# Altium schematic sheet Debug.SchDoc(Debug)
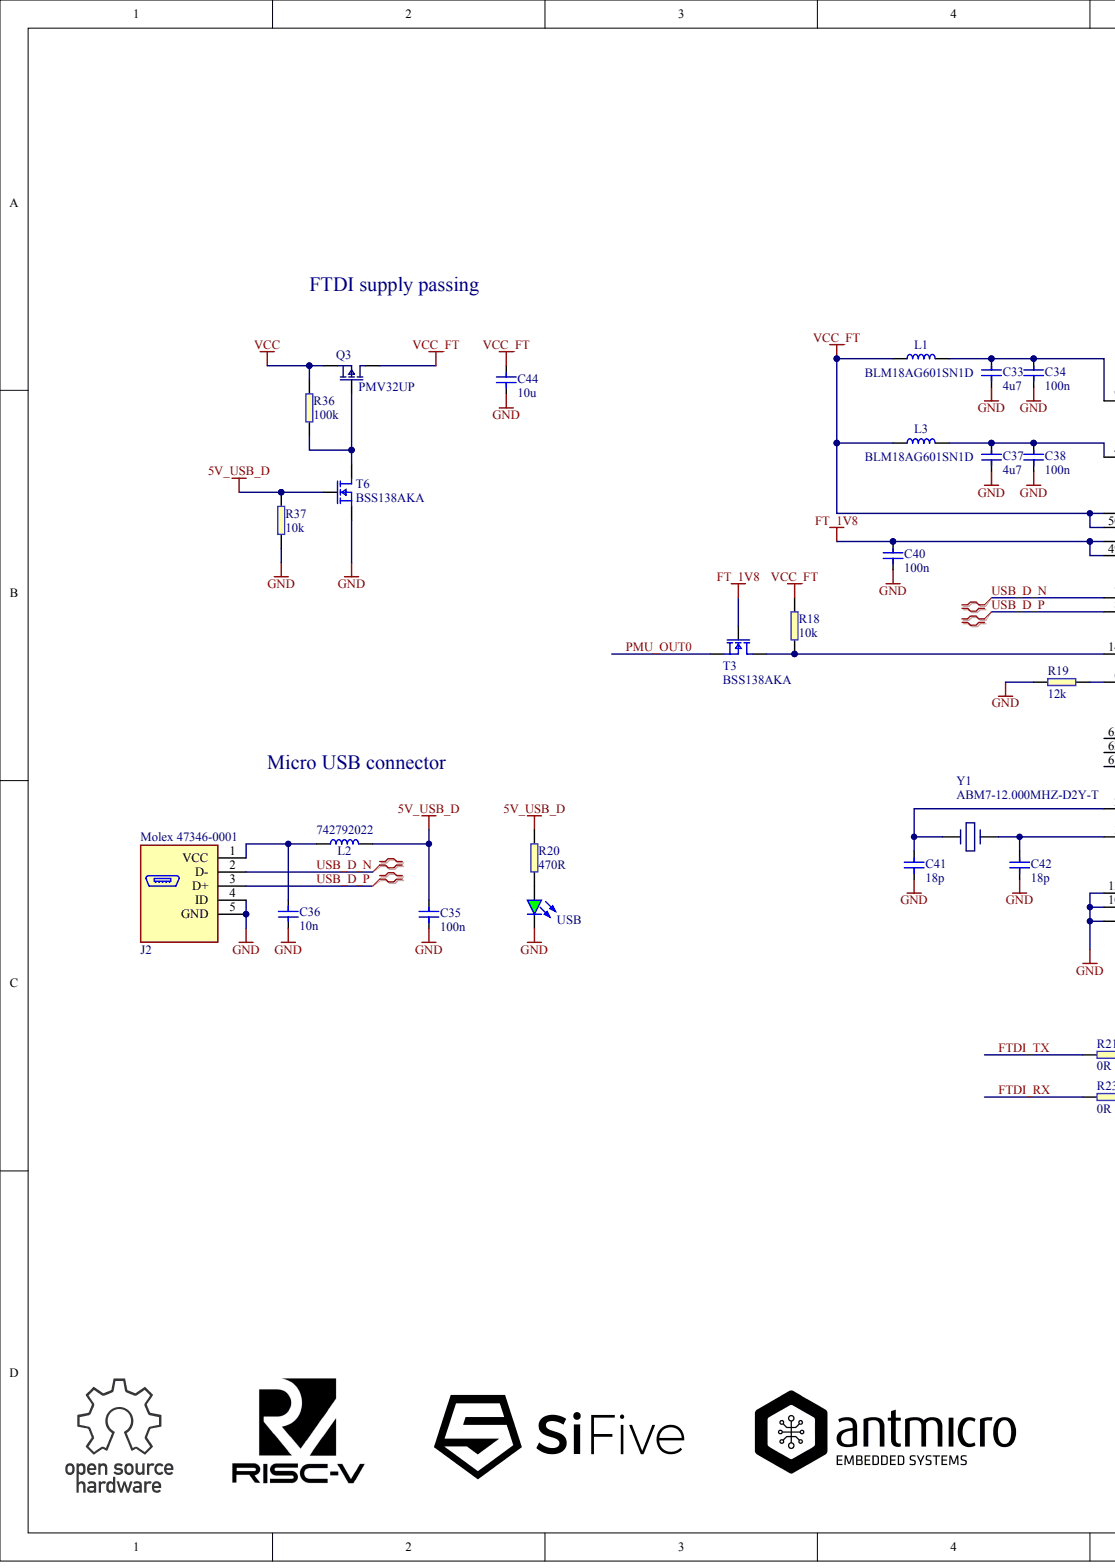
[diagram p0: Debug.SchDoc, left]
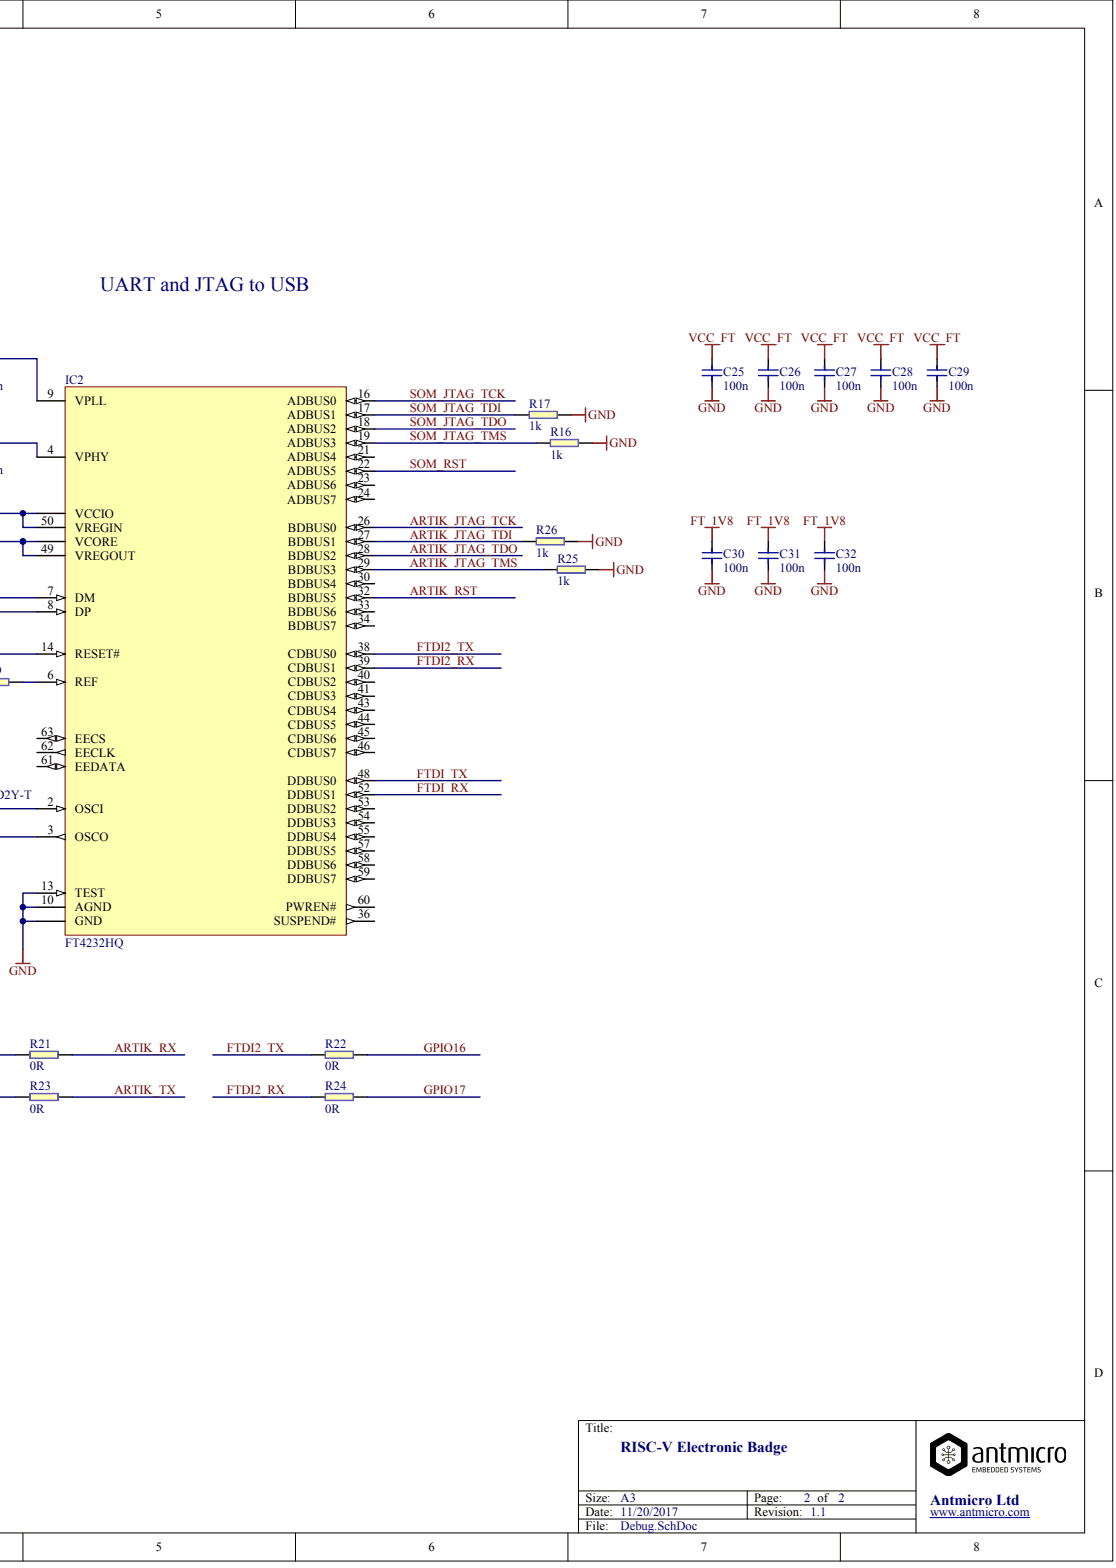
[diagram p1: Debug.SchDoc, right]

## Parts
C25  100n
C26  100n
C27  100n
C28  100n
C29  100n
C30  100n
C31  100n
C32  100n
C33  4u7
C34  100n
C35  100n
C36  10n
C37  4u7
C38  100n
C40  100n
C41  18p
C42  18p
C44  10u
IC2  FT4232HQ
J2  Manufacturer: Molex  (hidden comment: Molex 47346-0001)
L1  BLM18AG601SN1D
L2  742792022
L3  BLM18AG601SN1D
Q3  PMV32UP
R16  1k
R17  1k
R18  10k
R19  12k
R20  470R
R21  0R
R22  0R
R23  0R
R24  0R
R25  1k
R26  1k
R36  100k
R37  10k
T3  BSS138AKA
T6  BSS138AKA
USB  KPT-1608SGC  (value not drawn)
Y1  value ABM7-12.000MHZ-D2Y-T  (hidden comment: ABRACON - ABM7-12.000MHZ-D2Y-T - CRYSTAL, 12MHZ, 18PF, SMD)

## Nets
5V_USB_D: C35.2 L2.1 R20.2 R37.1 T6.1
FT_1V8: C30.2 C31.2 C32.2 C40.2 IC2.49(VREGOUT) IC2.VCORE T3.1
GND: C25.1 C26.1 C27.1 C28.1 C29.1 C30.1 C31.1 C32.1 C33.1 C34.1 C35.1 C36.2 C37.1 C38.1 C40.1 C41.1 C42.1 C44.1 IC2.10(AGND) IC2.13(TEST) IC2.GND J2.4(ID) J2.5(GND) R16.1 R17.1 R19.1 R25.1 R26.1 R37.2 T6.2 USB.K
VCC: Q3.2 R36.2
VCC_FT: C25.2 C26.2 C27.2 C28.2 C29.2 C44.2 IC2.50(VREGIN) IC2.VCCIO L1.1 L3.1 Q3.3 R18.1
ARTIK_JTAG_TCK: IC2.26(BDBUS0)
ARTIK_JTAG_TDI: IC2.27(BDBUS1) R26.2
ARTIK_JTAG_TDO: IC2.28(BDBUS2)
ARTIK_JTAG_TMS: IC2.29(BDBUS3) R25.2
ARTIK_RST: IC2.32(BDBUS5)
ARTIK_RX: R21.2
ARTIK_TX: R23.2
FTDI2_RX: IC2.39(CDBUS1) R24.1
FTDI2_TX: IC2.38(CDBUS0) R22.1
FTDI_RX: IC2.52(DDBUS1) R23.1
FTDI_TX: IC2.48(DDBUS0) R21.1
GPIO16: R22.2
GPIO17: R24.2
PMU_OUT0: T3.2
SOM_JTAG_TCK: IC2.16(ADBUS0)
SOM_JTAG_TDI: IC2.17(ADBUS1) R17.2
SOM_JTAG_TDO: IC2.18(ADBUS2)
SOM_JTAG_TMS: IC2.19(ADBUS3) R16.2
SOM_RST: IC2.22(ADBUS5)
USB_D_N: IC2.7(DM) J2.2(D-)
USB_D_P: IC2.8(DP) J2.3(D+)
NetC33_2: C33.2 C34.2 IC2.9(VPLL) L1.2
NetC36_1: C36.1 J2.1(VCC) L2.2
NetC37_2: C37.2 C38.2 IC2.4(VPHY) L3.2
NetC41_2: C41.2 IC2.2(OSCI) Y1.2
NetC42_2: C42.2 IC2.3(OSCO) Y1.1
NetIC2_6: IC2.6(REF) R19.2
NetIC2_14: IC2.14(RESET#) R18.2 T3.3
NetQ3_1: Q3.1 R36.1 T6.3
NetR20_1: R20.1 USB.A

Not connected: IC2 21(ADBUS4) 23(ADBUS6) 24(ADBUS7) 30(BDBUS4) 33(BDBUS6) 34(BDBUS7) 36(SUSPEND#) 40(CDBUS2) 41(CDBUS3) 43(CDBUS4) 44(CDBUS5) 45(CDBUS6) 46(CDBUS7) 53(DDBUS2) 54(DDBUS3) 55(DDBUS4) 57(DDBUS5) 58(DDBUS6) 59(DDBUS7) 60(PWREN#) 61(EEDATA) 62(EECLK) 63(EECS)

## Library data of the parts
C25-C32 C34 C38 C40 = (no symbol name): Part Number: C_100n_0402; Manufacturer: MULTICOMP; Part Symbol: MC0402X104M100CT; Value: 100n
C33 C37 = (no symbol name): Part Number: C_4u7_0402; Manufacturer: MURATA; Part Symbol: GRM155R60G475ME; Value: 4u7
C35 = (no symbol name): Part Number: C_100n_0603; Manufacturer: WALSIN; Part Symbol: 0603B104K500CT; Value: 100n
C36 = (no symbol name): Part Number: C_10n_0603; Manufacturer: AVX; Part Symbol: 06031C103JAT2A; Value: 10n
C41 C42 = (no symbol name): Part Number: C_18p_0402; Manufacturer: KEMET; Part Symbol: C0402C180J5GACAUTO; Value: 18p
C44 = (no symbol name): Part Number: C_10u_0402; Manufacturer: TDK; Part Symbol: C1005X5R0J106M050BC; Value: 10u
IC2 = (no symbol name): Manufacturer: FTDI; Part Symbol: FT4232HQ-R; Part Number: FT4232HQ; Value: FT4232HQ
J2 = (no symbol name): Part Number: CON-Molex-47346-0001; Part Symbol: 473460001; Value: Molex 47346-0001
L1 L3 = (no symbol name): Manufacturer: MURATA; Part Number: BLM18AG601SN1D; Value: BLM18AG601SN1D; Part Symbol: BLM18AG601SN1D
L2 = (no symbol name): Manufacturer: WURTH ELEKTRONIK; Part Number: 742792022; Value: 742792022; Part Symbol: 742792022
Q3 = (no symbol name): Manufacturer: NXP; Part Number: PMV32UP; Value: PMV32UP; Part Symbol: PMV32UP
R16 R17 R25 R26 = (no symbol name): Part Number: R_1k_0402; Manufacturer: MULTICOMP; Part Symbol: MCMR04X1001FTL; Value: 1k
R18 R37 = (no symbol name): Part Number: R_10k_0402; Manufacturer: MULTICOMP; Part Symbol: MCMR04X1002FTL; Value: 10k
R19 = (no symbol name): Part Number: R_12k_0402; Manufacturer: VISHAY; Part Symbol: CRCW040212K0FKEDHP; Value: 12k
R20 = (no symbol name): Part Number: R_470R_0603; Manufacturer: BOURNS; Part Symbol: CR0603-JW-471GLF; Value: 470R
R21-R24 = (no symbol name): Part Number: R_0R_0603; Manufacturer: BOURNS; Part Symbol: CR0603-J/-000ELF; Value: 0R
R36 = (no symbol name): Part Number: R_100k_0402; Manufacturer: VISHAY; Part Symbol: CRCW0402100KFKEDHP; Value: 100k
T3 T6 = (no symbol name): Part Number: BSS138; Manufacturer: NXP; Value: BSS138AKA; Part Symbol: BSS138AKA
USB = (no symbol name): Part Number: LED_G; Manufacturer: KINGBRIGHT; Value: KPT-1608SGC; Part Symbol: KPT-1608SGC
Y1 = (no symbol name): Part Number: CRYSTAL_12MHz_SMD_6.0x3.5mm; Manufacturer: ABRACON; Part Symbol: ABM7-12.000MHZ-D2Y-T
